# Altium schematic sheet [9]-Power Supply.SchDoc
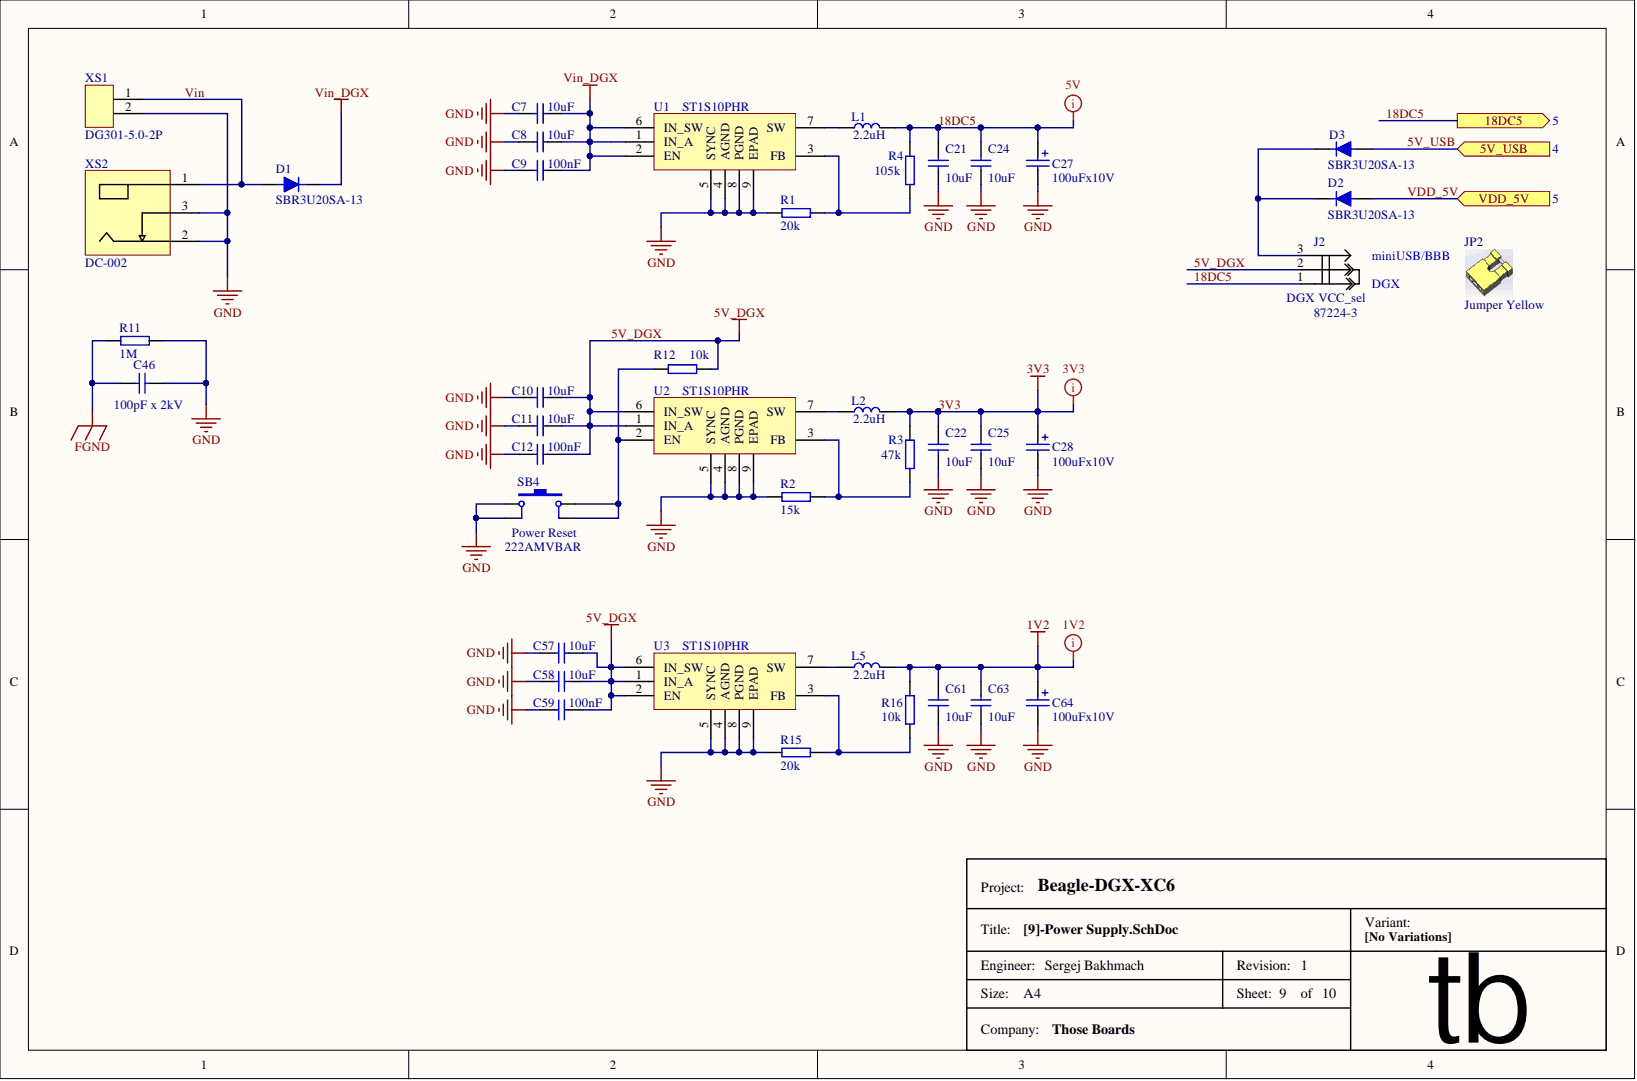
[diagram p0: [9]-Power Supply.SchDoc]

## Parts
C7  value 10uF  [C0805]  (hidden comment: CAP)
C8  value 10uF  [C0805]  (hidden comment: CAP)
C9  value 100nF  [C0603]  (hidden comment: CAP)
C10  value 10uF  [C0805]  (hidden comment: CAP)
C11  value 10uF  [C0805]  (hidden comment: CAP)
C12  value 100nF  [C0603]  (hidden comment: CAP)
C21  value 10uF  [C0805]  (hidden comment: CAP)
C22  value 10uF  [C0805]  (hidden comment: CAP)
C24  value 10uF  [C0805]  (hidden comment: CAP)
C25  value 10uF  [C0805]  (hidden comment: CAP)
C27  value 100uFx10V  [CAP_CASE_C]  (hidden comment: uF)
C28  value 100uFx10V  [CAP_CASE_C]  (hidden comment: uF)
C46  value 100pF x 2kV  [C1210]  (hidden comment: CAP)
C57  value 10uF  [C0805]  (hidden comment: CAP)
C58  value 10uF  [C0805]  (hidden comment: CAP)
C59  value 100nF  [C0603]  (hidden comment: CAP)
C61  value 10uF  [C0805]  (hidden comment: CAP)
C63  value 10uF  [C0805]  (hidden comment: CAP)
C64  value 100uFx10V  [CAP_CASE_C]  (hidden comment: uF)
D1  value SBR3U20SA-13  Manufacturer Part: SBR3U20SA-13  [SMA]  (hidden comment: Diode)
D2  value SBR3U20SA-13  Manufacturer Part: SBR3U20SA-13  [SMA]  (hidden comment: Diode)
D3  value SBR3U20SA-13  Manufacturer Part: SBR3U20SA-13  [SMA]  (hidden comment: Diode)
J2  DGX VCC_sel  value 87224-3  Manufacturer Part: 87224-3  [Jumper_3pin_yellow]
JP2  value Jumper Yellow  Supplier Part: Jumper  (hidden comment: VCC_sel)  (mechanical)
L1  value 2.2uH  [LTF5022]  (hidden comment: L_LTF5022)
L2  value 2.2uH  [LTF5022]  (hidden comment: L_LTF5022)
L5  value 2.2uH  [LTF5022]  (hidden comment: L_LTF5022)
R1  value 20k  [0603_RESISTOR]  (hidden comment: Resistor)
R2  value 15k  [0603_RESISTOR]  (hidden comment: Resistor)
R3  value 47k  [0603_RESISTOR]  (hidden comment: Resistor)
R4  value 105k  [0603_RESISTOR]  (hidden comment: Resistor)
R11  value 1M  [0805_resistor]  (hidden comment: Resistor)
R12  value 10k  [0603_RESISTOR]  (hidden comment: Resistor)
R15  value 20k  [0603_RESISTOR]  (hidden comment: Resistor)
R16  value 10k  [0603_RESISTOR]  (hidden comment: Resistor)
SB4  Power Reset  value 222AMVBAR  Manufacturer Part: 222AMVBAR  [Key 6.2x6.2]
U1  ST1S10  value ST1S10PHR  Manufacturer Part: ST1S10PHR  [SO8_PWR_pad]
U2  ST1S10  value ST1S10PHR  Manufacturer Part: ST1S10PHR  [SO8_PWR_pad]
U3  ST1S10  value ST1S10PHR  Manufacturer Part: ST1S10PHR  [SO8_PWR_pad]
XS1  DG301-5.0-2p  [DG301-5.0-2P]
XS2  value DC-002  [PJ-002AH]  (hidden comment: PWR_JCONN_21 smd)

## Ports
5V_USB, 18DC5, VDD_5V

## Nets
1V2: C61.2 C63.2 C64.1 L5.2 R16.2
FGND: C46.1 R11.1
GND: C7.1 C8.1 C9.2 C10.1 C11.1 C12.2 C21.1 C22.1 C24.1 C25.1 C27.2 C28.2 C46.2 C57.1 C58.1 C59.2 C61.1 C63.1 C64.2 R1.1 R2.1 R11.2 R15.1 SB4.1 SB4.3 U1.4(AGND) U1.5(SYNC) U1.8(PGND) U1.9(EPAD) U2.4(AGND) U2.5(SYNC) U2.8(PGND) U2.9(EPAD) U3.4(AGND) U3.5(SYNC) U3.8(PGND) U3.9(EPAD) XS1.2 XS2.2 XS2.3
VIN_DGX: C7.2 C8.2 C9.1 D1.2 U1.1(IN_A) U1.2(EN) U1.6(IN_SW)
3V3: C22.2 C25.2 C28.1 L2.2 R3.1
5V_DGX: C10.2 C11.2 C12.1 C57.2 C58.2 C59.1 J2.2 R12.2 U2.1(IN_A) U2.6(IN_SW) U3.1(IN_A) U3.2(EN) U3.6(IN_SW)
5V_USB: D3.1 ; port 5V_USB
18DC5: C21.2 C24.2 C27.1 J2.1 L1.2 R4.1 ; port 18DC5
VDD_5V: D2.1 ; port VDD_5V
VIN: D1.1 XS1.1 XS2.1
NetD2_2: D2.2 D3.2 J2.3
NetL1_1: L1.1 U1.7(SW)
NetL2_1: L2.1 U2.7(SW)
NetL5_1: L5.1 U3.7(SW)
NetR1_2: R1.2 R4.2 U1.3(FB)
NetR2_2: R2.2 R3.2 U2.3(FB)
NetR12_1: R12.1 SB4.2 SB4.4 U2.2(EN)
NetR15_2: R15.2 R16.1 U3.3(FB)

## Library data of the parts
C7 C8 C10 C11 C21 C22 C24 C25 C57 C58 C61 C63 = CAP: Manufacturer: Murata; Manufacturer Part: GRM21BC81C106KE15L; Type of Component: Ceramic Capacitor; Component Kind: Standard; Manufacturer Link: http://psearch.en.murata.com/capacitor/result/smd/?status=all&pid=GRM21BC81C106KE15L; Package / Case: 0805; Supplier: mouser; Supplier Link: http://www.mouser.com/ProductDetail/Murata/GRM21BC81C106KE15L/?qs=%2fha2pyFadugq0yC%2fv5omHdWffW%252bX1z%252bEjQXmyIECJNTTTKySHodREy42G6WZAHxu; Supplier Part: 81-GRM21BC81C106KE5L; Library Name: RPI-VITA.IntLib
C9 C12 C59 = CAP: Manufacturer: Vishay / Vitramon; Manufacturer Part: VJ0603Y104JXJPW1BC; Type of Component: Ceramic Capacitor; Component Kind: Standard; Manufacturer Link: http://www.vishay.com/product?docid=28548; Package / Case: 0603; Supplier: mouser; Supplier Link: http://www.mouser.com/ProductDetail/Vishay/VJ0603Y104JXJPW1BC/?qs=%2fha2pyFaduidGoO0X7aK7Xgs%2fjxfhnLiK6SRw4Lz0%252bZK3PJ8DddTrQ%3d%3d; Supplier Part: 77-VJ0603Y104JXJPBC; Library Name: RPI-VITA.IntLib
C27 C28 C64 = Cap_pol_tant: Manufacturer: AVX; Manufacturer Part: TPSC107K010S0075; Type of Component: Tantalum Capacitor; Component Kind: Standard; Manufacturer Link: http://www.avx.com/prodinfo_productdetail.asp?I=82&K=search&C=&word=TPSC107K010S0075&form=number&Number=; Package / Case: Case C; Supplier: mouser; Supplier Link: http://www.mouser.ee/ProductDetail/AVX/TPSC107K010S0075/?qs=sGAEpiMZZMuEN2agSAc2pj5RGSp5%252bR392wzwmTGvCpg%3d; Supplier Part: 581-TPSC107K010S0075; Library Name: RPI-VITA.IntLib
C46 = CAP: Manufacturer: Murata Electronics; Manufacturer Part: GRM32A7U3D101JW31D; Type of Component: Ceramic Capacitor; Component Kind: Standard; Manufacturer Link: http://psearch.en.murata.com/capacitor/product/GRM32A7U3D101JW31%23.html; Package / Case: 1210; Supplier: mouser; Supplier Link: http://www.mouser.ee/ProductDetail/Murata-Electronics/GRM32A7U3D101JW31D/?qs=sGAEpiMZZMs0AnBnWHyRQFQYdTDrJniYFw4lyPz2MF4%3d; Supplier Part: 81-GRM32A7U3D101JW31; Library Name: RPI-VITA.IntLib
D1-D3 = Diode: Schottky Diodes & Rectifiers; Manufacturer: Diodes Incorporated; Component Kind: Standard; Manufacturer Link: http://www.diodes.com/search?type=0&value=SBR3U20SA-13; Package / Case: SMA; Supplier: mouser; Supplier Link: http://www.mouser.ee/ProductDetail/Diodes-Incorporated/SBR3U20SA-13/?qs=sGAEpiMZZMtQ8nqTKtFS%2fE6cG%252brOIQfRLc3x%2fXaLBa0%3d; Supplier Part: 621-SBR3U20SA-13; Type of Component: Diode; Library Name: RPI-VITA.IntLib
J2 = jumper_3pin: Manufacturer: TE Connectivity / AMP; Type of Component: Jumper; Component Kind: Standard; Manufacturer Link: http://www.te.com/usa-en/product-87224-3.html#pdp-related-products-inner; Package / Case: Header 1 x 3; Supplier: mouser; Supplier Link: http://www.mouser.ee/ProductDetail/TE-Connectivity-AMP/87224-3/?qs=sGAEpiMZZMs%252bGHln7q6pm48SVpWlpfsErguO%2fp0873w%3d; Supplier Part: 571-872243; Library Name: RPI-VITA.IntLib
JP2 = jumper yellow: Manufacturer: Great Electric; Manufacturer Part: Jumper Cap Shunts Short Circuit Cap; Package / Case: 2.54mm; Supplier: aliexpress.com; Supplier Link: http://www.aliexpress.com/item/500pcs-lot-Asssorted-Color-2-54mm-Standard-Circuit-Board-Jumper-Cap-Shunts-Short-Circuit-Cap-CGKCH119/32344305619.html?spm=2114.03010208.3.80.nPPmoy&ws_ab_test=searchweb201556_1,searchweb201644_1_505_506_503_504_502_10001_10002_10017_10010_10005_10011_10006_10003_10004_10009_10007_10008,searchweb201560_5,searchweb1451318400_-1,searchweb1451318411_6452&btsid=16d11777-edde-4755-b8b5-9adacb495b09; Type of Component: Jumper Yellow; Library Name: RPI-VITA.IntLib
L1 L2 L5 = L_LTF5022: Manufacturer: TDK; Manufacturer Part: LTF5022T-2R2N3R2-LC; Type of Component: Inductor; Component Kind: Standard; Manufacturer Link: http://product.tdk.com/inductor/ind/detailed_information.php?lang=en&part_no=LTF5022T-2R2N3R2-LC; Package / Case: 5.2 mm x 5 mm; Supplier: mouser; Supplier Link: http://www.mouser.ee/search/ProductDetail.aspx?TDK%2fLTF5022T-2R2N3R2-LC%2f&qs=%2fha2pyFadui2dkPtc8Qb7GgS2MXg2uuOAtrBGixOx605UNMElKnYgkaM4wRA6%2fNS; Supplier Part: 810-LTF5022T2R2N3R2L; Library Name: RPI-VITA.IntLib
R1 R15 = Resistor: Manufacturer: Vishay / Dale; Manufacturer Part: CRCW060320K0FKEA; Component Kind: Standard; Manufacturer Link: http://www.vishay.com/product?docid=20035; Package / Case: 0603; Supplier: mouser; Supplier Link: http://www2.mouser.com/ProductDetail/Vishay-Dale/CRCW060320K0FKEA/?qs=sGAEpiMZZMvdGkrng054tzs1OVvhhRzmqGSefucNZhs%3d; Supplier Part: 71-CRCW0603-20K-E3; Type of Component: Resistor; Library Name: RPI-VITA.IntLib
R2 = Resistor: Manufacturer: Vishay / Dale; Manufacturer Part: CRCW060315K0FKEA; Component Kind: Standard; Manufacturer Link: http://www.vishay.com/product?docid=20035; Package / Case: 0603; Supplier: mouser; Supplier Link: http://www2.mouser.com/ProductDetail/Vishay-Dale/CRCW060315K0FKEA/?qs=sGAEpiMZZMvdGkrng054tzs1OVvhhRzmFqyUoR13SvQ%3d; Supplier Part: 71-CRCW0603-15K-E3; Type of Component: Resistor; Library Name: RPI-VITA.IntLib
R3 = Resistor: Manufacturer: Vishay / Dale; Manufacturer Part: CRCW060347K0FKEA; Component Kind: Standard; Manufacturer Link: http://www.vishay.com/product?docid=20035; Package / Case: 0603; Supplier: mouser; Supplier Link: http://www2.mouser.com/ProductDetail/Vishay-Dale/CRCW060347K0FKEA/?qs=sGAEpiMZZMvdGkrng054t4HRUGKGWf0J%252bEfP0bj7%252bjU%3d; Supplier Part: 71-CRCW0603-47K-E3; Type of Component: Resistor; Library Name: RPI-VITA.IntLib
R4 = Resistor: Manufacturer: Vishay / Dale; Manufacturer Part: CRCW0603105KFKEA; Component Kind: Standard; Manufacturer Link: http://www.vishay.com/product?docid=20035; Package / Case: 0603; Supplier: mouser; Supplier Link: http://www2.mouser.com/ProductDetail/Vishay-Dale/CRCW0603105KFKEA/?qs=sGAEpiMZZMvdGkrng054tw79ugaueG8BiPYssBAGfpI%3d; Supplier Part: 71-CRCW0603-105K-E3; Type of Component: Resistor; Library Name: RPI-VITA.IntLib
R11 = Resistor: Manufacturer: Vishay / Dale; Manufacturer Part: CRCW08051M00FKEA; Component Kind: Standard; Manufacturer Link: http://www.vishay.com/product?docid=20035; Package / Case: 0805; Supplier: mouser; Supplier Link: http://www2.mouser.com/ProductDetail/Vishay-Dale/CRCW08051M00FKEA/?qs=sGAEpiMZZMvdGkrng054txEw7b1YnvGubiAZzjveInE%3d; Supplier Part: 71-CRCW0805-1.0M-E3; Type of Component: Resistor; Library Name: RPI-VITA.IntLib
R12 R16 = Resistor: Manufacturer: Vishay / Dale; Manufacturer Part: CRCW060310K0FKEA; Component Kind: Standard; Manufacturer Link: http://www.vishay.com/product?docid=20035; Package / Case: 0603; Supplier: mouser; Supplier Link: http://www.mouser.com/ProductDetail/Vishay-Dale/CRCW060310K0FKEA/?qs=sGAEpiMZZMu61qfTUdNhGyptJL5EcUtREGTsEKG7Lak%3d; Supplier Part: 71-CRCW0603-10K-E3; Type of Component: Resistor; Library Name: RPI-VITA.IntLib
SB4 = SB_Tactile: Tactile Switches; Manufacturer: E-Switch; Type of Component: Tact Switch; Component Kind: Standard; Package / Case: 6.2 x 6.2 x 5; Supplier: mouser; Supplier Link: http://www.mouser.ee/ProductDetail/CTS-Electronic-Components/222AMVBAR/?qs=sGAEpiMZZMsgGjVA3toVBB5GWLGpesfgV6sVMBFzGDw%3d; Supplier Part: 774-222AMVBAR; Library Name: RPI-VITA.IntLib
U1-U3 = ST1S10: Manufacturer: STMicroelectronics; Type of Component: Voltage Regulator; Component Kind: Standard; Manufacturer Link: http://www.st.com/web/en/catalog/sense_power/FM142/CL1456/SC355/PF188180?s_searchtype=keyword; Package / Case: SOIC-8; Supplier: mouser; Supplier Link: http://www.mouser.com/ProductDetail/STMicroelectronics/ST1S10PHR/?qs=sGAEpiMZZMvMXbh32ZmHAKWWuRxuuGix; Supplier Part: 511-ST1S10PHR; Library Name: RPI-VITA.IntLib
XS1 = DG301-5.0-2p: Manufacturer: HK-Ada; Type of Component: Terminal Block; Component Kind: Standard; Manufacturer Link: DG301-5.0-2P; Package / Case: DG301-5.0; Supplier: HK-Ada; Supplier Part: DG301-5.0-2P; Value: DG301-5.0-2P; Library Name: RPI-VITA.IntLib
XS2 = PWR_JCONN_21 smd: DC Power Jack 2.1mm smd; Type of Component: DC Plug; Component Kind: Standard; Supplier: aliexpress.com; Supplier Link: http://aliexpress.com/item/100PCS-Copper-SMD-5-5MM-2-1mm-DC-Socket-2-1MM-DC-Plug-PCB-Panel-Mount/32226428004.html?spm=2114.03020208.3.204.JEPBAQ&ws_ab_test=searchweb201556_1,searchweb201644_1_79_78_77_82_83_80_62_81,searchweb201560_5; Library Name: RPI-VITA.IntLib
